# Altium schematic sheet [3]-GLCD_128X64.SchDoc([3]-GLCD_128X64)
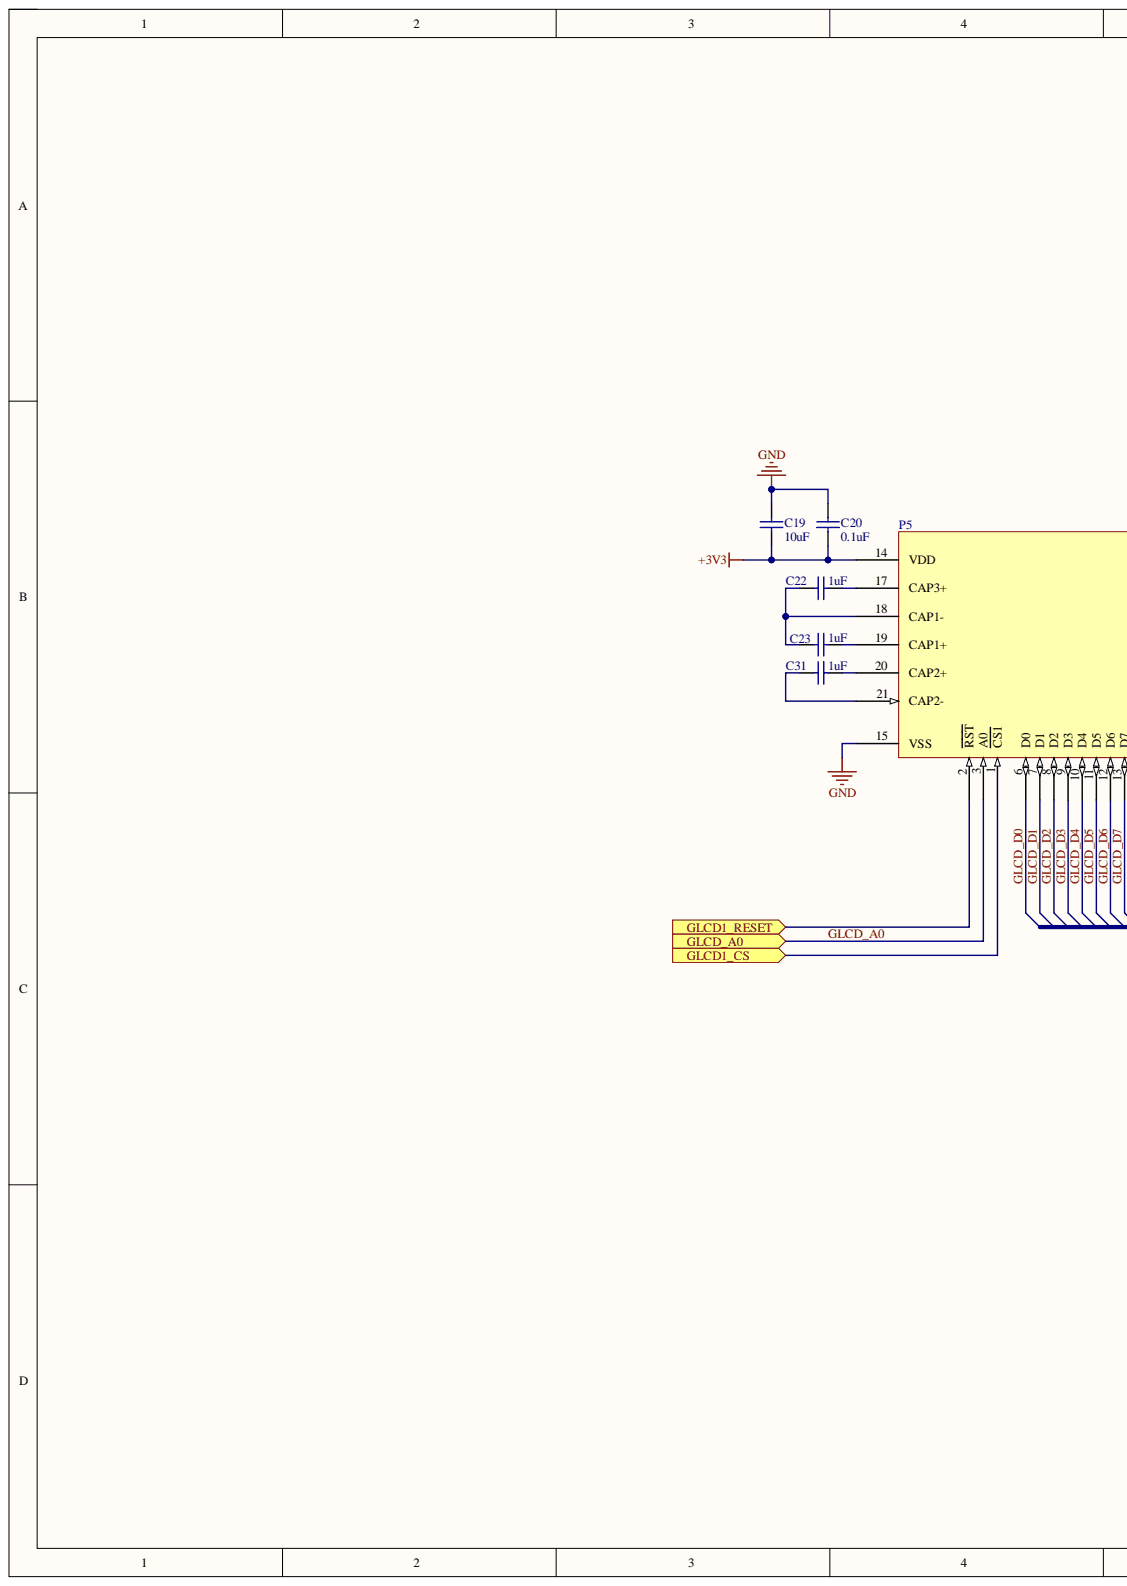
[diagram p0: [3]-GLCD_128X64.SchDoc, left]
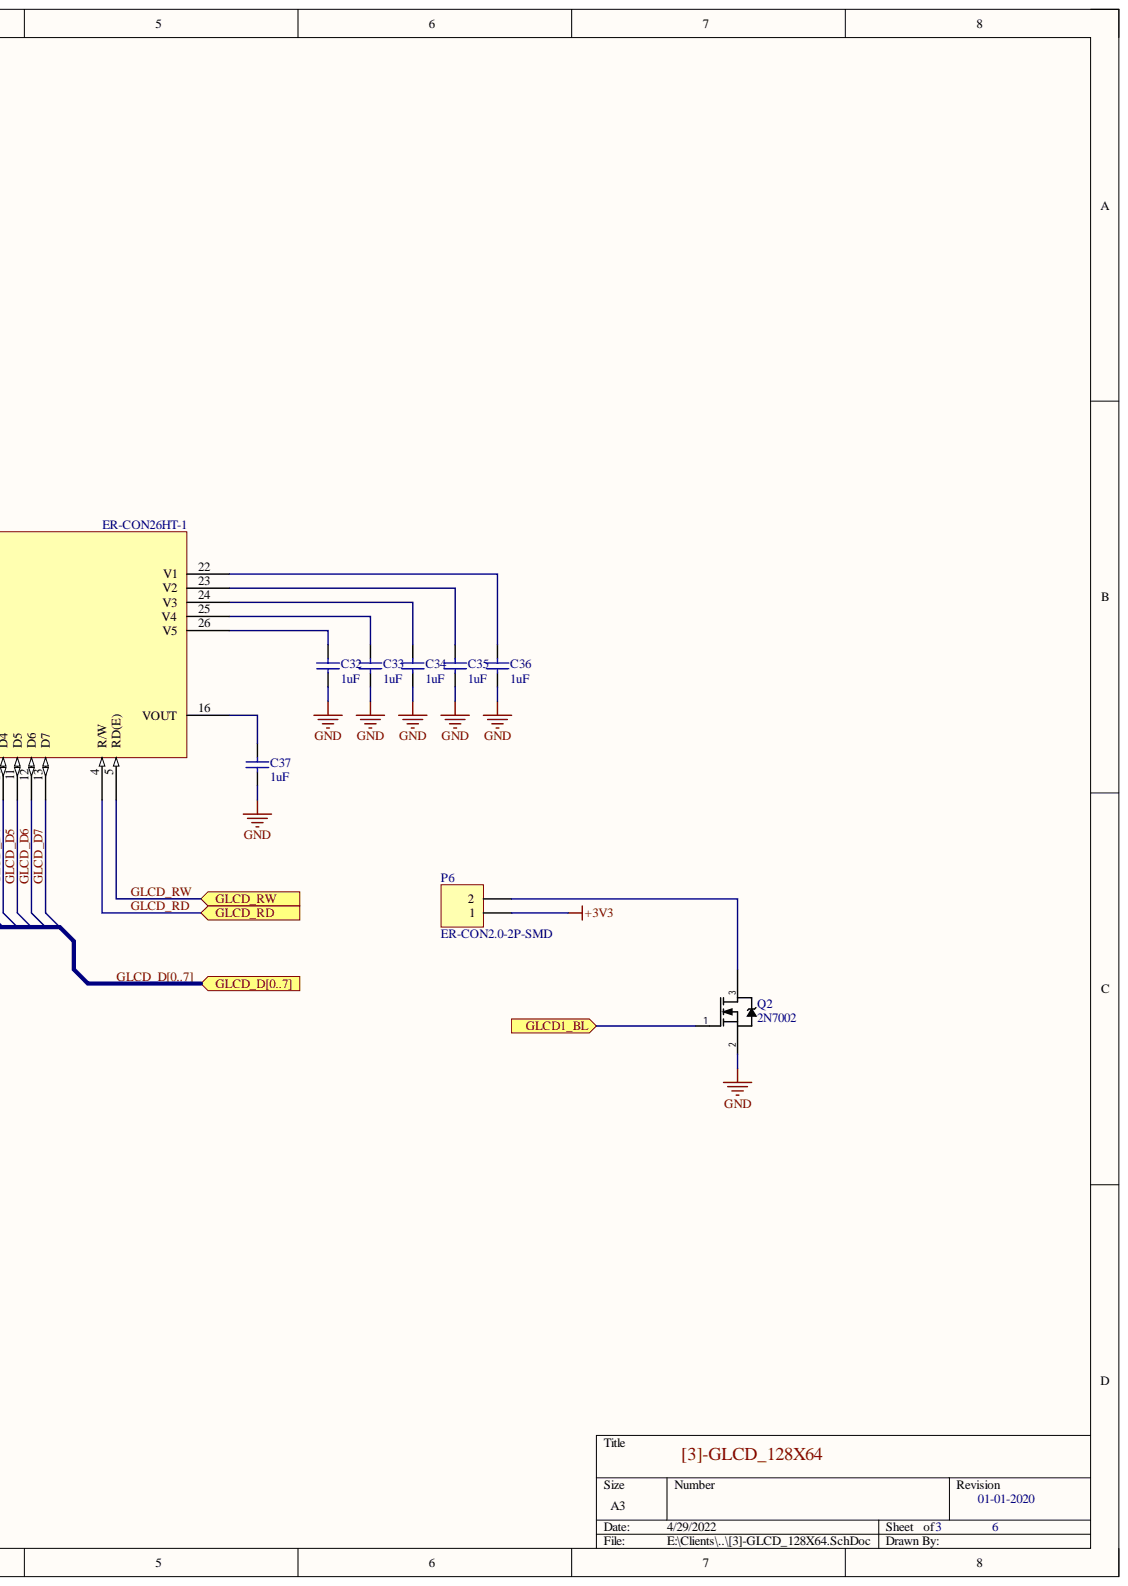
[diagram p1: [3]-GLCD_128X64.SchDoc, right]

## Parts
C19  10uF  [CAPC1608N]
C20  0.1uF  [CAPC1608N]
C22  1uF  [CAPC1608N]
C23  1uF  [CAPC1608N]
C31  1uF  [CAPC1608N]
C32  1uF  [CAPC1608N]
C33  1uF  [CAPC1608N]
C34  1uF  [CAPC1608N]
C35  1uF  [CAPC1608N]
C36  1uF  [CAPC1608N]
C37  1uF  [CAPC1608N]
P5  ER-CON26HT-1  [ER-CON26HT-1]
P6  ER-CON2.0-2P-SMD  [ER-CON2.0-2P-SMD]
Q2  2N7002  [SOT23-3N]

## Ports
GLCD1_BL, GLCD1_CS, GLCD1_RESET, GLCD_A0, GLCD_D0, GLCD_D1, GLCD_D2, GLCD_D3, GLCD_D4, GLCD_D5, GLCD_D6, GLCD_D7, GLCD_D[0..7], GLCD_RD, GLCD_RW

## Nets
+3V3: C19.1 C20.1 P5.14(VDD) P6.1
GND: C19.2 C20.2 C32.1 C33.1 C34.1 C35.1 C36.1 C37.1 P5.15(VSS) Q2.2
GLCD1_BL: Q2.1 ; port GLCD1_BL
GLCD1_CS: P5.1(CS1) ; port GLCD1_CS
GLCD1_RESET: P5.2(RST) ; port GLCD1_RESET
GLCD_A0: P5.3(A0) ; port GLCD_A0
GLCD_D0: P5.6(D0) ; port GLCD_D[0..7]  [also labelled GLCD_D]
GLCD_D1: P5.7(D1) ; port GLCD_D[0..7]  [also labelled GLCD_D]
GLCD_D2: P5.8(D2) ; port GLCD_D[0..7]  [also labelled GLCD_D]
GLCD_D3: P5.9(D3) ; port GLCD_D[0..7]  [also labelled GLCD_D]
GLCD_D4: P5.10(D4) ; port GLCD_D[0..7]  [also labelled GLCD_D]
GLCD_D5: P5.11(D5) ; port GLCD_D[0..7]  [also labelled GLCD_D]
GLCD_D6: P5.12(D6) ; port GLCD_D[0..7]  [also labelled GLCD_D]
GLCD_D7: P5.13(D7) ; port GLCD_D[0..7]  [also labelled GLCD_D]
GLCD_RD: P5.4(R/W) ; port GLCD_RD
GLCD_RW: P5.5(RD(E)) ; port GLCD_RW
NetC22_1: C22.1 C23.1 P5.18(CAP1-)
NetC22_2: C22.2 P5.17(CAP3+)
NetC23_2: C23.2 P5.19(CAP1+)
NetC31_1: C31.1 P5.21(CAP2-)
NetC31_2: C31.2 P5.20(CAP2+)
NetC32_2: C32.2 P5.26(V5)
NetC33_2: C33.2 P5.25(V4)
NetC34_2: C34.2 P5.24(V3)
NetC35_2: C35.2 P5.23(V2)
NetC36_2: C36.2 P5.22(V1)
NetC37_2: C37.2 P5.16(VOUT)
NetP6_2: P6.2 Q2.3

## Library data of the parts
C19 = GRM188R61A106KE69D: CAP CER 10UF 10V X5R 0603; Manufacturer 1: Murata; Tolerance: 10%; Capacitance: 10uF; Case Code (Imperial): 0603; Case Code (Metric): 1608; Case/Package: 0603; Component Kind: Standard; Depth: 0.8mm; Dielectric: X5R; Height: 0.8mm; Lead Free: Lead Free; Length: 1.6mm; Manufacturer Part Number 1: GRM188R61A106KE69D; Max Operating Temperature: 85°C; Min Operating Temperature: -55°C; Mount: Surface Mount; Packaging: Tape and Reel; Pricing 1: 1=0.22, 10=0.149, 100=0.0754, 500=0.05158, 1000=0.04563 (USD); REACH SVHC: No SVHC; Stock 1: 40000; Supplier 1: Digi-Key; Supplier Part Number 1: 490-10474-1-ND; Thickness: 0.037inch; Voltage: 10V; Voltage Rating: 10V; Voltage Rating (DC): 10V; Width: 0.031inch; Library Name: bitsnio.SchLib
C20 = CC0603KRX7R8BB104: CAP CER 0.1UF 25V X7R 0603; Manufacturer 1: Yageo; Capacitance: 100nF; Tolerance: 10%; Case Code (Imperial): 0603; Case Code (Metric): 1608; Case/Package: 0603; Component Kind: Standard; ComponentLink1Description: https://datasheet.ciiva.com/11786/0900766b80c10176-11786120.pdf; ComponentLink1URL: https://datasheet.ciiva.com/11786/0900766b80c10176-11786120.pdf; ComponentLink2Description: https://datasheet.ciiva.com/144/mlccorderingcode-144075.pdf; ComponentLink2URL: https://datasheet.ciiva.com/144/mlccorderingcode-144075.pdf; ComponentLink3Description: https://datasheet.ciiva.com/17434/upy-gphc-x7r-6-3v-to-50v-8-17434263.pdf; ComponentLink3URL: https://datasheet.ciiva.com/17434/upy-gphc-x7r-6-3v-to-50v-8-17434263.pdf; ComponentLink4Description: https://datasheet.ciiva.com/26829/getdatasheetpartid-403577-26829431.pdf; ComponentLink4URL: https://datasheet.ciiva.com/26829/getdatasheetpartid-403577-26829431.pdf; ComponentLink5Description: https://datasheet.ciiva.com/11587/upy-gphc-x7r-6-3v-to-50v-8-11587208.pdf; ComponentLink5URL: https://datasheet.ciiva.com/11587/upy-gphc-x7r-6-3v-to-50v-8-11587208.pdf; ComponentLink6Description: https://datasheet.ciiva.com/13389/upy-gphc-x7r-6-3v-to-50v-8-13389352.pdf; ComponentLink6URL: https://datasheet.ciiva.com/13389/upy-gphc-x7r-6-3v-to-50v-8-13389352.pdf; ComponentLink7Description: https://datasheet.ciiva.com/28450/1489800-28450584.pdf; ComponentLink7URL: https://datasheet.ciiva.com/28450/1489800-28450584.pdf; ComponentLink8Description: https://datasheet.ciiva.com/144/mlccorderingcode-144073.pdf; ComponentLink8URL: https://datasheet.ciiva.com/144/mlccorderingcode-144073.pdf; ComponentLink9Description: https://datasheet.ciiva.com/144/mlccorderingcode-144074.pdf; ComponentLink9URL: https://datasheet.ciiva.com/144/mlccorderingcode-144074.pdf; ComponentLink10Description: https://datasheet.ciiva.com/7978/1489800-7978155.pdf; ComponentLink10URL: https://datasheet.ciiva.com/7978/1489800-7978155.pdf; Composition: Ceramic; Depth: 0.8mm; Dielectric: X7R; Halogen Free: Halogen Free; Height: 0.8mm; Lead Free: Lead Free; Length: 1.6mm; Manufacturer Part Number 1: CC0603KRX7R8BB104; Max Operating Temperature: 125°C; Min Operating Temperature: -55°C; Mount: Surface Mount; Number of Pins: 2; Package Quantity: 1; Packaging: Tape and Reel; Pricing 1: 1=0.1, 10=0.074, 100=0.0329, 500=0.02352, 1000=0.01848 (USD); Radiation Hardening: No; REACH SVHC: Unknown; Stock 1: 40000; Supplier 1: Digi-Key; Supplier Part Number 1: 311-1341-1-ND; Termination: SMD/SMT; Thickness: 0.035inch; Voltage: 25V; Voltage Rating: 25V; Voltage Rating (DC): 25V; Width: 0.8mm; Library Name: bitsnio.SchLib
C22 C23 C31-C37 = CL10A105KL8NNNC: CAP CER 1UF 35V X5R 0603; Manufacturer 1: Samsung; Capacitance: 1 µF; Description 1: 1µF ±10% 35V X5R Ceramic Capacitor -55°C ~ 85°C Surface Mount, MLCC 0603 (1608 Metric) 0.063" L x 0.031" W (1.60mm x 0.80mm); Tolerance (%): ±10 %; Case Size/Dimension: 0603; Component Kind: Standard; ComponentLink1Description: https://datasheet.ciiva.com/25773/cl10a105kl8nnnc-25773809.pdf; ComponentLink1URL: https://datasheet.ciiva.com/25773/cl10a105kl8nnnc-25773809.pdf; ComponentLink2Description: https://datasheet.ciiva.com/16202/clseries-16202344.pdf; ComponentLink2URL: https://datasheet.ciiva.com/16202/clseries-16202344.pdf; Dielectric: X5R; Manufacturer Part Number 1: CL10A105KL8NNNC; Pricing 1: 1=0.16, 10=0.117, 100=0.055, 500=0.0386, 1000=0.0319 (USD); Rated Voltage: 35 V; Stock 1: 65224; Supplier 1: Digi-Key; Supplier Part Number 1: 1276-1861-1-ND; Library Name: bitsnio.SchLib
P5 = ER-CON26HT-1: CONN ZIF 26 TOP PIN SMT; Component Kind: Standard; Library Name: bitsnio.SchLib
P6 = ER-CON2.0-2P-SMD: CONN 2 POS SMD 2MM PITCH; Component Kind: Standard; Library Name: bitsnio.SchLib
Q2 = 2N7002: MOSFET N-CH 60V 115MA SOT-23; Manufacturer 1: ON Semiconductor / Fairchild; Drain to Source Resistance: 1.2R; Element Configuration: Single; Gate to Source Voltage (Vgs): 20V; Input Capacitance: 50pF; Max Power Dissipation: 200mW; Nominal Vgs: 2.1V; Number of Elements: 1; On-State Resistance: 5.3R; Rds On Max: 7.5R; Case/Package: SOT-23; Component Kind: Standard; ComponentLink1Description: https://datasheet.ciiva.com/931/2n7000-931777.pdf; ComponentLink1URL: https://datasheet.ciiva.com/931/2n7000-931777.pdf; ComponentLink2Description: https://datasheet.ciiva.com/27746/47-0120-27746881.pdf; ComponentLink2URL: https://datasheet.ciiva.com/27746/47-0120-27746881.pdf; ComponentLink3Description: https://datasheet.ciiva.com/1254/2n7002-1254451.pdf; ComponentLink3URL: https://datasheet.ciiva.com/1254/2n7002-1254451.pdf; ComponentLink4Description: https://datasheet.ciiva.com/15137/getdatasheetpartid-93547-15137771.pdf; ComponentLink4URL: https://datasheet.ciiva.com/15137/getdatasheetpartid-93547-15137771.pdf; ComponentLink5Description: https://datasheet.ciiva.com/931/2n7000-931762.pdf; ComponentLink5URL: https://datasheet.ciiva.com/931/2n7000-931762.pdf; ComponentLink6Description: https://datasheet.ciiva.com/931/2n7000-931763.pdf; ComponentLink6URL: https://datasheet.ciiva.com/931/2n7000-931763.pdf; ComponentLink7Description: https://datasheet.ciiva.com/931/2n7000-931764.pdf; ComponentLink7URL: https://datasheet.ciiva.com/931/2n7000-931764.pdf; ComponentLink8Description: https://datasheet.ciiva.com/1254/660093-1254452.pdf; ComponentLink8URL: https://datasheet.ciiva.com/1254/660093-1254452.pdf; ComponentLink9Description: https://datasheet.ciiva.com/1188/660093-1188359.pdf; ComponentLink9URL: https://datasheet.ciiva.com/1188/660093-1188359.pdf; ComponentLink10Description: https://datasheet.ciiva.com/1188/660093-1188361.pdf; ComponentLink10URL: https://datasheet.ciiva.com/1188/660093-1188361.pdf; ComponentLink11Description: https://datasheet.ciiva.com/17007/2n7002-17007243.pdf; ComponentLink11URL: https://datasheet.ciiva.com/17007/2n7002-17007243.pdf; ComponentLink12Description: https://datasheet.ciiva.com/3304/nds7002a-3304380.pdf; ComponentLink12URL: https://datasheet.ciiva.com/3304/nds7002a-3304380.pdf; ComponentLink13Description: https://datasheet.ciiva.com/3304/nds7002a-3304381.pdf; ComponentLink13URL: https://datasheet.ciiva.com/3304/nds7002a-3304381.pdf; ComponentLink14Description: https://datasheet.ciiva.com/22655/660093-22655230.pdf; ComponentLink14URL: https://datasheet.ciiva.com/22655/660093-22655230.pdf; Contact Plating: Tin,Matte; Continuous Drain Current (ID): 115mA; Current: 115mA; Current Rating: 115mA; Drain to Source Breakdown Voltage: 60V; Drain to Source Voltage (Vdss): 60V; Dual Supply Voltage: 60V; Height: 0.93mm; Lead Free: Lead Free; Length: 2.92mm; Manufacturer Part Number 1: 2N7002; Max Operating Temperature: 150°C; Min Operating Temperature: -55°C; Mount: Surface Mount; Number of Pins: 3; Package Quantity: 1; Packaging: Tape and Reel; Power Dissipation: 200mW; Pricing 1: 1=0.33, 10=0.304, 100=0.17, 500=0.09108, 1000=0.06193 (USD); Radiation Hardening: No; REACH SVHC: No SVHC; Stock 1: 111118; Supplier 1: Digi-Key; Supplier Part Number 1: 2N7002NCT-ND; Threshold Voltage: 2.1V; Voltage: 60V; Voltage Rating (DC): 60V; Width: 3.05mm; Library Name: bitsnio.SchLib
